# Altium schematic sheet Sheet3.SchDoc
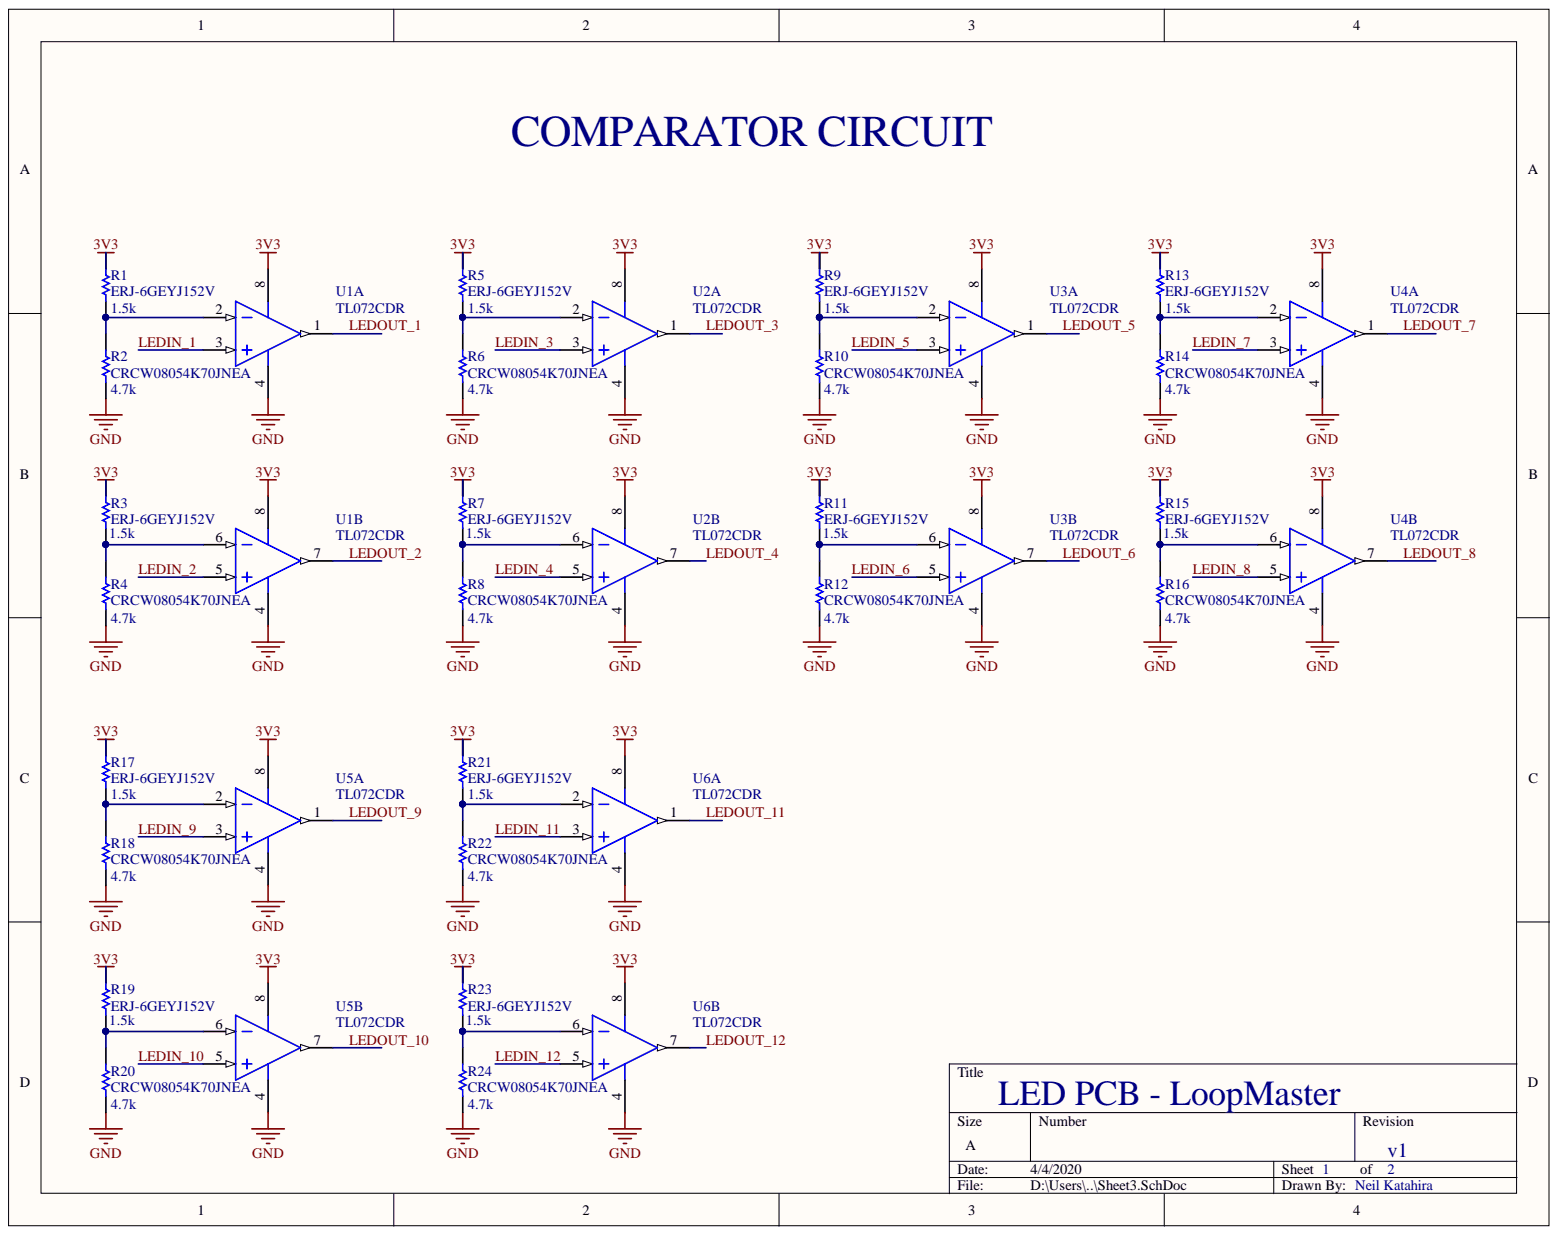
[diagram p0: Sheet3.SchDoc]

## Parts
R1  ERJ-6GEYJ152V  [RESC2013X70X40NL20T20]
R2  CRCW08054K70JNEA  [RESC2013X50X30LL20T20]
R3  ERJ-6GEYJ152V  [RESC2013X70X40NL20T20]
R4  CRCW08054K70JNEA  [RESC2013X50X30LL20T20]
R5  ERJ-6GEYJ152V  [RESC2013X70X40NL20T20]
R6  CRCW08054K70JNEA  [RESC2013X50X30LL20T20]
R7  ERJ-6GEYJ152V  [RESC2013X70X40NL20T20]
R8  CRCW08054K70JNEA  [RESC2013X50X30LL20T20]
R9  ERJ-6GEYJ152V  [RESC2013X70X40NL20T20]
R10  CRCW08054K70JNEA  [RESC2013X50X30LL20T20]
R11  ERJ-6GEYJ152V  [RESC2013X70X40NL20T20]
R12  CRCW08054K70JNEA  [RESC2013X50X30LL20T20]
R13  ERJ-6GEYJ152V  [RESC2013X70X40NL20T20]
R14  CRCW08054K70JNEA  [RESC2013X50X30LL20T20]
R15  ERJ-6GEYJ152V  [RESC2013X70X40NL20T20]
R16  CRCW08054K70JNEA  [RESC2013X50X30LL20T20]
R17  ERJ-6GEYJ152V  [RESC2013X70X40NL20T20]
R18  CRCW08054K70JNEA  [RESC2013X50X30LL20T20]
R19  ERJ-6GEYJ152V  [RESC2013X70X40NL20T20]
R20  CRCW08054K70JNEA  [RESC2013X50X30LL20T20]
R21  ERJ-6GEYJ152V  [RESC2013X70X40NL20T20]
R22  CRCW08054K70JNEA  [RESC2013X50X30LL20T20]
R23  ERJ-6GEYJ152V  [RESC2013X70X40NL20T20]
R24  CRCW08054K70JNEA  [RESC2013X50X30LL20T20]
U1  TL072CDR  [D0008A_M]  (parts A,B)
U2  TL072CDR  [D0008A_M]  (parts A,B)
U3  TL072CDR  [D0008A_M]  (parts A,B)
U4  TL072CDR  [D0008A_M]  (parts A,B)
U5  TL072CDR  [D0008A_M]  (parts A,B)
U6  TL072CDR  [D0008A_M]  (parts A,B)

## Nets
3V3: R1.2 R3.2 R5.2 R7.2 R9.2 R11.2 R13.2 R15.2 R17.2 R19.2 R21.2 R23.2 U1.8 U2.8 U3.8 U4.8 U5.8 U6.8
GND: R2.1 R4.1 R6.1 R8.1 R10.1 R12.1 R14.1 R16.1 R18.1 R20.1 R22.1 R24.1 U1.4 U2.4 U3.4 U4.4 U5.4 U6.4
LEDIN_1: U1.3
LEDIN_2: U1.5
LEDIN_3: U2.3
LEDIN_4: U2.5
LEDIN_5: U3.3
LEDIN_6: U3.5
LEDIN_7: U4.3
LEDIN_8: U4.5
LEDIN_9: U5.3
LEDIN_10: U5.5
LEDIN_11: U6.3
LEDIN_12: U6.5
LEDOUT_1: U1.1
LEDOUT_2: U1.7
LEDOUT_3: U2.1
LEDOUT_4: U2.7
LEDOUT_5: U3.1
LEDOUT_6: U3.7
LEDOUT_7: U4.1
LEDOUT_8: U4.7
LEDOUT_9: U5.1
LEDOUT_10: U5.7
LEDOUT_11: U6.1
LEDOUT_12: U6.7
NetR1_1: R1.1 R2.2 U1.2
NetR3_1: R3.1 R4.2 U1.6
NetR5_1: R5.1 R6.2 U2.2
NetR7_1: R7.1 R8.2 U2.6
NetR9_1: R9.1 R10.2 U3.2
NetR11_1: R11.1 R12.2 U3.6
NetR13_1: R13.1 R14.2 U4.2
NetR15_1: R15.1 R16.2 U4.6
NetR17_1: R17.1 R18.2 U5.2
NetR19_1: R19.1 R20.2 U5.6
NetR21_1: R21.1 R22.2 U6.2
NetR23_1: R23.1 R24.2 U6.6

## Library data of the parts
R1 R3 R5 R7 R9 R11 R13 R15 R17 R19 R21 R23 = CMP-2001-00355-1: Manufacturer: Panasonic; Component Kind: Standard; Library Name: Altium Content Vault; Part Number: ERJ-6GEYJ152V
R2 R4 R6 R8 R10 R12 R14 R16 R18 R20 R22 R24 = CMP-2001-03967-1: Manufacturer: Vishay Dale; Component Kind: Standard; Library Name: Altium Content Vault; Part Number: CRCW08054K70JNEA
U1-U6 = CMP-0017-00628-2: Dual Low-Noise JFET-Input General-Purpose Operational Amplifier, 7 to 36 V, 0 to 70 degC, 8-pin SOIC (D8), Green (RoHS & no Sb/Br); Manufacturer: Texas Instruments; CMRR(Min)(dB): 70; GBW(Typ)(MHz): 3; IIB(Max)(pA): 200; Iq per channel(Max)(mA): 2.5; Number of Channels: 2; Offset Drift(Typ)(uV/C): 18; Rating: Catalog; Slew Rate(Typ)(V/us): 13; Sub Family: General Purpose Amplifier, High Supply Voltage Amplifier (>=30V); Total Supply Voltage(Max)(+5V is 5, +/-5V is 10): 36; Total Supply Voltage(Min)(+5V is 5, +/-5V is 10): 7; Vio (25C)(Max)(mV): 10; Code_JEDEC: MS-012; Component Kind: Standard; ComponentLink1Description: Manufacturer URL; ComponentLink1URL: http://www.ti.com/; ComponentLink2Description: Datasheet; ComponentLink2URL: http://www.ti.com/lit/ds/symlink/tl072.pdf; ComponentLink3Description: Package Specification; ComponentLink3URL: http://www.ti.com/litv/pdf/msoi002j; DatasheetVersion: SLOS080J; Eco-Plan: Green (RoHS & no Sb/Br); Library Name: Altium Content Vault; Mounting Technology: Surface Mount; PackageDescription: 8-Pin Plastic Small Outline, Body 4.9 x 3.9 mm, Pitch 1.27 mm; PackageReference: D0008A; PackageVersion: 4040047-3/M, 06/2011; PartNumber: TL072CDR; Vn at 1kHz(Typ)(nV/rtHz): 18
